# Altium schematic sheet Sensors.SchDoc
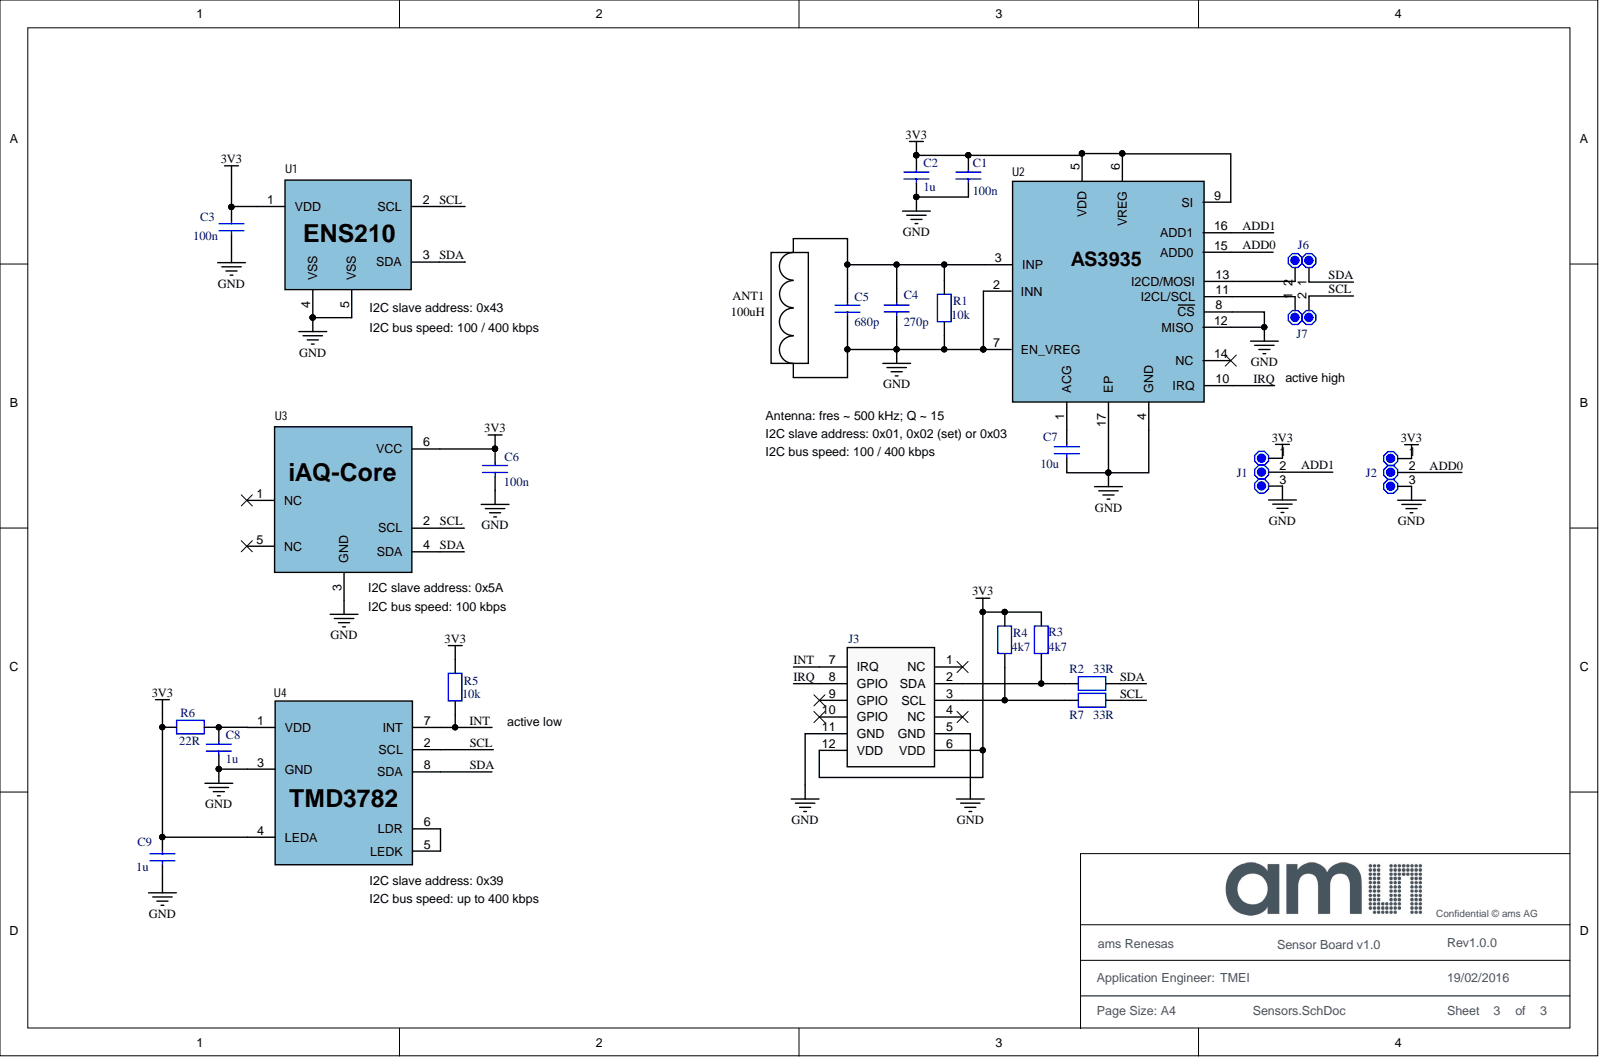
[diagram p0: Sensors.SchDoc]

## Parts
ANT1  100uH  [3.5x11.7mm]
C1  100n  [C0402]
C2  1u  [C0402]
C3  100n  [C0402]
C4  270p  [C0402]
C5  680p  [C0402]
C6  100n  [C0402]
C7  10u  [C0402]
C8  1u  [C0402]
C9  1u  [C0402]
J1  Solder Jumper x3  [Solder Jumper x3]  (value not drawn)  (no BOM)
J2  Solder Jumper x3  [Solder Jumper x3]  (value not drawn)  (no BOM)
J3  PMOD_12pin_Header  [PMOD_12pin_male]  (value not drawn)
J6  Solder Jumper x2  [Solder Jumper x2]  (value not drawn)  (no BOM)
J7  Solder Jumper x2  [Solder Jumper x2]  (value not drawn)  (no BOM)
R1  10k  [R0402]
R2  33R  [R0402]
R3  4k7  [R0402]
R4  4k7  [R0402]
R5  10k  [R0402]
R6  22R  [R0402]
R7  33R  [R0402]
U1  ENS210  [ENS210]
U2  AS3935-BQFT  [AMS-MLPQ-16-4000X4000DE-2675X2675TP_N]  (value not drawn)
U3  iAQ-Core  [iAQ-Core]
U4  TMD3782  [TMD3782]

## Nets
3V3: C1.1 C2.1 C3.1 C6.1 C9.1 J1.1 J2.1 J3.6(VDD) J3.12(VDD) R3.1 R4.1 R5.1 R6.1 U1.1(VDD) U2.5(VDD) U2.6(VREG) U2.9(SI) U3.6(VCC) U4.4(LEDA)
GND: ANT1.1 C1.2 C2.2 C3.2 C4.2 C5.2 C6.2 C7.2 C8.2 C9.2 J1.3 J2.3 J3.5(GND) J3.11(GND) R1.2 U1.4(VSS) U1.5(VSS) U2.2(INN) U2.4(GND) U2.7(EN_VREG) U2.8(CS) U2.12(MISO) U2.17(EP) U3.3(GND) U4.3(GND)
ADD0: J2.2 U2.15(ADD0)
ADD1: J1.2 U2.16(ADD1)
INT: J3.7(IRQ) R5.2 U4.7(INT)
IRQ: J3.8(GPIO) U2.10(IRQ)
SCL: J7.2 R7.2 U1.2(SCL) U3.2(SCL) U4.2(SCL)
SDA: J6.1 R2.2 U1.3(SDA) U3.4(SDA) U4.8(SDA)
NetANT1_2: ANT1.2 C4.1 C5.1 R1.1 U2.3(INP)
NetC7_1: C7.1 U2.1(ACG)
NetC8_1: C8.1 R6.2 U4.1(VDD)
NetJ3_2: J3.2(SDA) R2.1 R3.2
NetJ3_3: J3.3(SCL) R4.2 R7.1
NetJ6_2: J6.2 U2.13(I2CD/MOSI)
NetJ7_1: J7.1 U2.11(I2CL/SCL)
NetU4_5: U4.5(LEDK) U4.6(LDR)

Not connected: J3 1(NC) 4(NC) 9(GPIO) 10(GPIO); U2 14(NC); U3 1(NC) 5(NC)

## Library data of the parts
ANT1 = MA5532-AE: Manufacturer: Coilcraft; Component_Description: 100uH Coil; Component Kind: Standard; Library Name: Renesas_ams_Lib.IntLib; Manufacturer Part Number: MA5532-AE; Supplier 1: Coilcraft
C1-C9 = C_0402: Component Kind: Standard; Library Name: Renesas_ams_Lib.IntLib; Supplier 1: Farnell
  C1 C3 C6: Supplier Part Number 1: 2210748
  C2 C8 C9: Supplier Part Number 1: 2112701RL
  C4: Supplier Part Number 1: 2210774
  C5: Supplier Part Number 1: 2210788
  C7: Supplier Part Number 1: 2346872RL
R1-R7 = R_0402: Component Kind: Standard; Library Name: Renesas_ams_Lib.IntLib; Supplier 1: Farnell
  R1 R5: Supplier Part Number 1: 1738864
  R2 R7: Supplier Part Number 1: 1738830
  R3 R4: Supplier Part Number 1: 1358060
  R6: Supplier Part Number 1: 1738828
U2 = AS3935-BQFT: Franklin Lightning Sensor(TM) IC; Component Kind: Standard; Library Name: Renesas_ams_Lib.IntLib
J1 J2 = Solder Jumper x3: Library Name: Renesas_ams_Lib.IntLib
J3 = PMOD_12pin_Header: Component Kind: Standard; Library Name: Renesas_ams_Lib.IntLib; Supplier 1: Farnell; Supplier Part Number 1: 2032654
J6 J7 = Solder Jumper x2: Library Name: Renesas_ams_Lib.IntLib
U1 = ENS210: Component Kind: Standard; Library Name: Renesas_ams_Lib.IntLib
U3 = iAQ-Core: Component Kind: Standard; Library Name: Renesas_ams_Lib.IntLib
U4 = TMD3782: Component Kind: Standard; Library Name: Renesas_ams_Lib.IntLib
